# Altium schematic sheet Sheet1.SchDoc
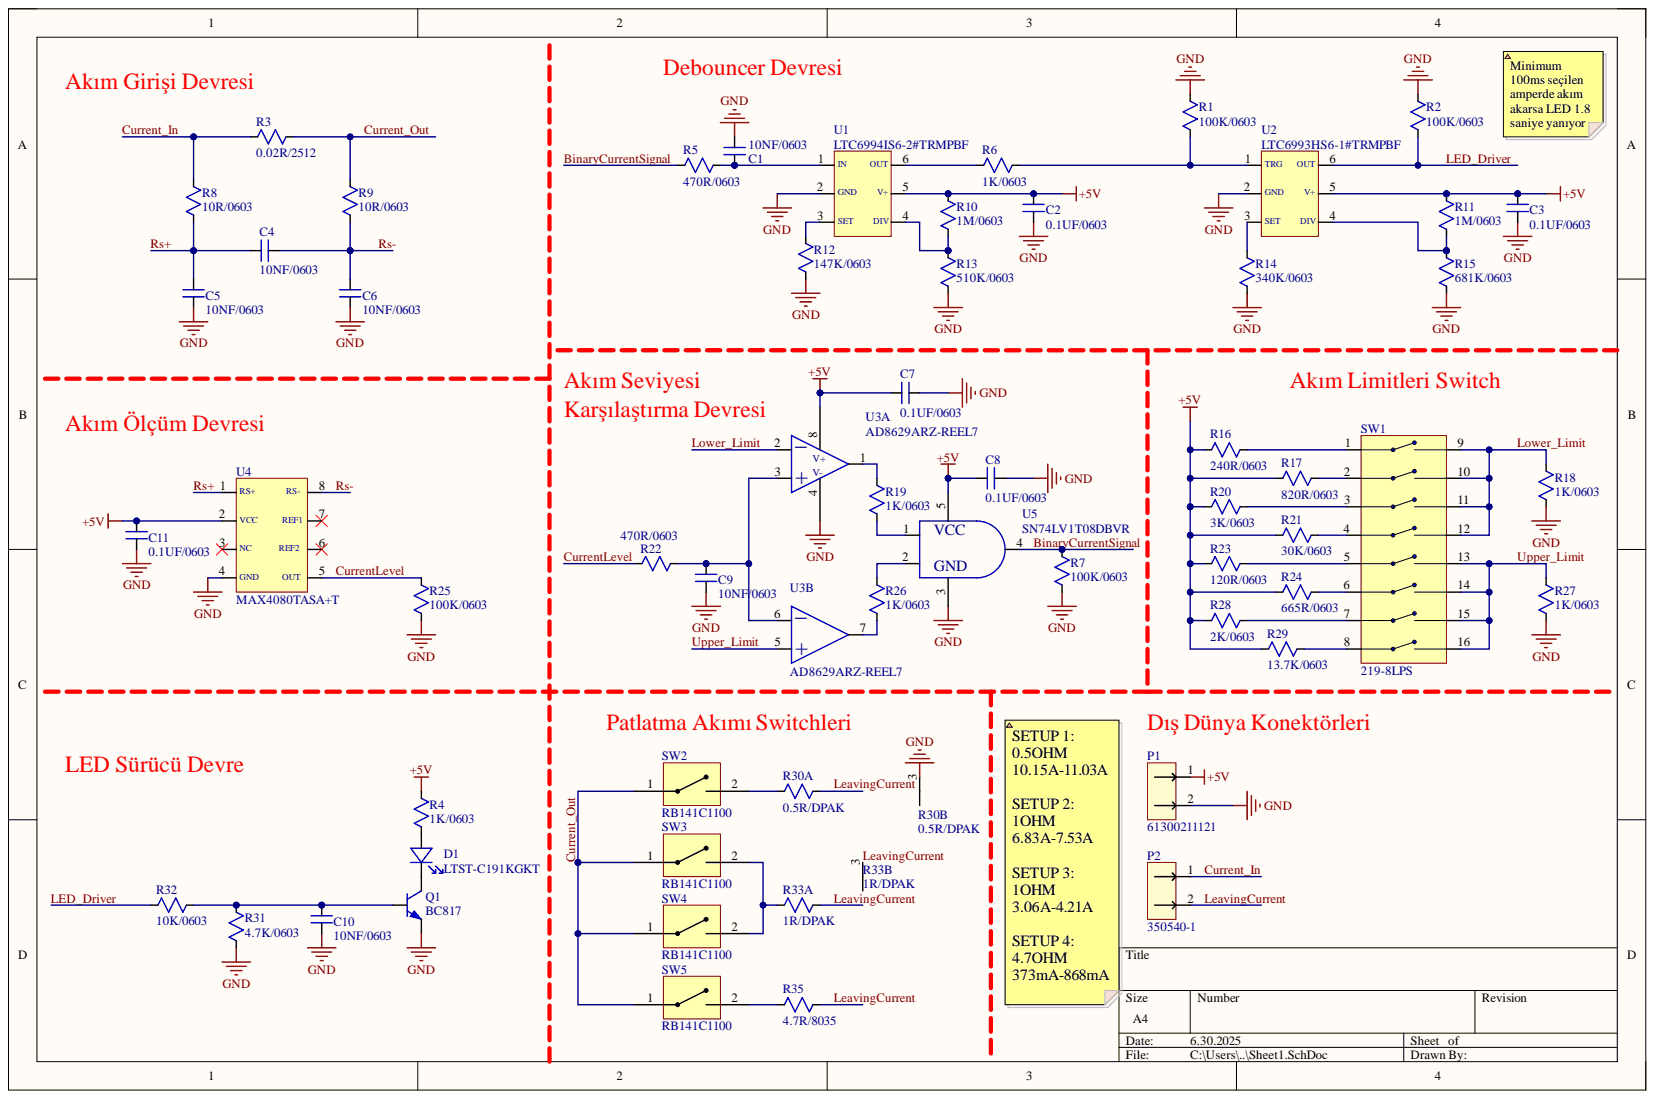
[diagram p0: Sheet1.SchDoc]

## Parts
C1  10NF/0603  [CAP 0603]
C2  0.1UF/0603  [CAP 0603]
C3  0.1UF/0603  [CAP 0603]
C4  10NF/0603  [CAP 0603]
C5  10NF/0603  [CAP 0603]
C6  10NF/0603  [CAP 0603]
C7  0.1UF/0603  [CAP 0603]
C8  0.1UF/0603  [CAP 0603]
C9  10NF/0603  [CAP 0603]
C10  10NF/0603  [CAP 0603]
C11  0.1UF/0603  [CAP 0603]
D1  LTST-C191KGKT  [LTST-C191KGKT]
P1  61300211121  [61300211121]
P2  350540-1  [350540-1]
Q1  BC817  [BC817-40,215]
R1  100K/0603  [RES0603]
R2  100K/0603  [RES0603]
R3  0.02R/2512  [RES2512]
R4  1K/0603  [RES0603]
R5  470R/0603  [RES0603]
R6  1K/0603  [RES0603]
R7  100K/0603  [RES0603]
R8  10R/0603  [RES0603]
R9  10R/0603  [RES0603]
R10  1M/0603  [RES0603]
R11  1M/0603  [RES0603]
R12  147K/0603  [RES0603]
R13  510K/0603  [RES0603]
R14  340K/0603  [RES0603]
R15  681K/0603  [RES0603]
R16  240R/0603  [RES0603]
R17  820R/0603  [RES0603]
R18  1K/0603  [RES0603]
R19  1K/0603  [RES0603]
R20  3K/0603  [RES0603]
R21  30K/0603  [RES0603]
R22  470R/0603  [RES0603]
R23  120R/0603  [RES0603]
R24  665R/0603  [RES0603]
R25  100K/0603  [RES0603]
R26  1K/0603  [RES0603]
R27  1K/0603  [RES0603]
R28  2K/0603  [RES0603]
R29  13.7K/0603  [RES0603]
R30  0.5R/DPAK  [PWR163S-25-R500FE]  (parts A,B)
R31  4.7K/0603  [RES0603]
R32  10K/0603  [RES0603]
R33  1R/DPAK  [PWR163S-25-1R00FE]  (parts A,B)
R35  4.7R/8035  [SM8035JT4R70]
SW1  219-8LPS  [219-8LPS]
SW2  RB141C1100  [RB141C1100]
SW3  RB141C1100  [RB141C1100]
SW4  RB141C1100  [RB141C1100]
SW5  RB141C1100  [RB141C1100]
U1  LTC6994IS6-2#TRMPBF  [LTC6994IS6-2#TRMPBF_1]
U2  LTC6993HS6-1#TRMPBF  [LTC6993HS6-1#TRMPBF]
U3  AD8629ARZ-REEL7  [AD8629ARZ_REEL7]  (parts A,B)
U4  MAX4080TASA+T  [MAX4080TASA+T]
U5  SN74LV1T08DBVR  [SN74LV1T08DBVR]

## Nets
+5V: C2.1 C3.1 C7.1 C8.2 C11.2 P1.1 R4.2 R10.2 R11.2 R16.1 R17.1 R20.2 R21.1 R23.1 R24.1 R28.1 R29.1 U1.5(V+) U2.5(V+) U3.8(V+) U4.2(VCC) U5.5
GND: C1.2 C2.2 C3.2 C5.1 C6.1 C7.2 C8.1 C9.1 C10.1 C11.1 P1.2 Q1.2 R1.2 R2.2 R7.1 R12.1 R13.1 R14.1 R15.1 R18.1 R25.1 R27.1 R30.3 R31.1 U1.2(GND) U2.2(GND) U3.4(V-) U4.4(GND) U5.3
BinaryCurrentSignal: R5.1 R7.2 U5.4
Current_In: P2.1 R3.1 R8.2
Current_Out: R3.2 R9.2 SW2.1 SW3.1 SW4.1 SW5.1
CurrentLevel: R22.1 R25.2 U4.5(OUT)
LeavingCurrent: P2.2 R30.2 R33.2 R33.3 R35.2
LED_Driver: R2.1 R32.2 U2.6(OUT)
Lower_Limit: R18.2 SW1.9 SW1.10 SW1.11 SW1.12 U3.2
Rs+: C4.2 C5.2 R8.1 U4.1(RS+)
Rs-: C4.1 C6.2 R9.1 U4.8(RS-)
Upper_Limit: R27.2 SW1.13 SW1.14 SW1.15 SW1.16 U3.5(+)
NetC1_1: C1.1 R5.2 U1.1(IN)
NetC9_2: C9.2 R22.2 U3.3(+) U3.6
NetC10_2: C10.2 Q1.1 R31.2 R32.1
NetD1_1: D1.1 R4.1
NetD1_2: D1.2 Q1.3
NetR1_1: R1.1 R6.2 U2.1(TRG)
NetR6_1: R6.1 U1.6(OUT)
NetR10_1: R10.1 R13.2 U1.4(DIV)
NetR11_1: R11.1 R15.2 U2.4(DIV)
NetR12_2: R12.2 U1.3(SET)
NetR14_2: R14.2 U2.3(SET)
NetR16_2: R16.2 SW1.1
NetR17_2: R17.2 SW1.2
NetR19_1: R19.1 U5.1(VCC)
NetR19_2: R19.2 U3.1
NetR20_1: R20.1 SW1.3
NetR21_2: R21.2 SW1.4
NetR23_2: R23.2 SW1.5
NetR24_2: R24.2 SW1.6
NetR26_1: R26.1 U3.7
NetR26_2: R26.2 U5.2(GND)
NetR28_2: R28.2 SW1.7
NetR29_2: R29.2 SW1.8
NetR30_1: R30.1 SW2.2
NetR33_1: R33.1 SW3.2 SW4.2
NetR35_1: R35.1 SW5.2

Not connected: U4 3(NC) 6(REF2) 7(REF1)

## Library data of the parts
C1 C4-C6 C9 C10 = CC0603KPX7R9BB103: CAP CER 10000PF 50V X7R 0603; Component Kind: Standard; Library Name: PA_Test_Kartı_v2.SchLib
C2 C3 C7 C8 C11 = CC0603KPX7R9BB104: CAP CER 0.1UF 50V X7R 0603; Component Kind: Standard; Library Name: PA_Test_Kartı_v2.SchLib
D1 = LTST-C191KGKT: LED GREEN CLEAR SMD; Component Kind: Standard; Library Name: PA_Test_Kartı_v2.SchLib
P1 = 61300211121: CONN HEADER VERT 2POS 2.54MM 3A; Component Kind: Standard; Library Name: PA_Test_Kartı_v2.SchLib
P2 = 350540-1: CONN HEADER VERT 2POS 5.08MM 12A; Component Kind: Standard; Library Name: PA_Test_Kartı_v2.SchLib
Q1 = BC817-40,215: TRANS NPN 45V 0.5A TO236AB; Component Kind: Standard; Library Name: PA_Test_Kartı_v2.SchLib
R1 R2 R7 R25 = RC0603FR-07100KL: RES SMD 100 KOHM 1% 1/16W 0603; Component Kind: Standard
  R7 R25: Library Name: Integrated_Library1.IntLib
  R1 R2: Library Name: PA_Test_Kartı_v2.SchLib
R3 = CRA2512-FZ-R020ELF: RES POWER 0.02 OHM 1% 3W  CURR SENSE 2512; Component Kind: Standard; Library Name: PA_Test_Kartı_v2.SchLib
R4 R6 R18 R19 R26 R27 = RT0603BRD131KL: RES SMD 1K OHM 0.1% 1/10W 0603; Component Kind: Standard; Library Name: PA_Test_Kartı_v2.SchLib
R5 R22 = RC0603JR-07470RL: RES SMD 470 OHM 5% 1/10W 0603; Component Kind: Standard; Library Name: PA_Test_Kartı_v2.SchLib
R8 R9 = RT0603BRD0710RL: RES SMD 10 OHM 0.1% 1/10W 0603; Component Kind: Standard; Library Name: PA_Test_Kartı_v2.SchLib
R10 R11 = ERJ-3EKF1004V: RES SMD 1M OHM 1% 1/10W 0603; Component Kind: Standard; Library Name: PA_Test_Kartı_v2.SchLib
R12 = RC0603FR-07147KL: RES SMD 147K OHM 1% 1/16W 0603; Component Kind: Standard; Library Name: Integrated_Library1.IntLib
R13 = RC0603FR-07510KL: RES SMD 510K OHM 1% 1/10W 0603; Component Kind: Standard; Library Name: PA_Test_Kartı_v2.SchLib
R14 = RC0603FR-07340KL: RES SMD 340K OHM 1% 1/10W 0603; Component Kind: Standard; Library Name: PA_Test_Kartı_v2.SchLib
R15 = RMCF0603FT681K: RES  681K OHM 1% 1/10W 0603; Component Kind: Standard; Library Name: PA_Test_Kartı_v2.SchLib
R16 = RC0603FR-07240RL: RES SMD 240 OHM 1% 1/10W 0603; Component Kind: Standard; Library Name: PA_Test_Kartı_v2.SchLib
R17 = RC0603FR-07820RL: RES SMD 820 OHM 1% 1/10W 0603; Component Kind: Standard; Library Name: PA_Test_Kartı_v2.SchLib
R20 = ERJ-PA3J302V: RES SMD 3K OHM 5% 1/3W 0603; Component Kind: Standard; Library Name: PA_Test_Kartı_v2.SchLib
R21 = RC0603FR-1330KL: RES SMD 30K OHM 1% 1/10W 0603; Component Kind: Standard; Library Name: PA_Test_Kartı_v2.SchLib
R23 = ERA-3AEB121V: RES SMD 120 OHM 0.1% 1/10W 0603; Component Kind: Standard; Library Name: PA_Test_Kartı_v2.SchLib
R24 = RC0603FR-07665RL: RES SMD 665 OHM 1% 1/10W 0603; Component Kind: Standard; Library Name: PA_Test_Kartı_v2.SchLib
R28 = ERA-3AEB202V: RES SMD 2K OHM 0.1% 1/10W 0603; Component Kind: Standard; Library Name: PA_Test_Kartı_v2.SchLib
R29 = RMCF0603FT13K7: RES  13.7K OHM 1% 1/10W 0603; Component Kind: Standard; Library Name: PA_Test_Kartı_v2.SchLib
R30 = PWR163S-25-R500FE: RES POWER 0.5 OHM  25W 1% Non-Standart DPAK; Component Kind: Standard; Library Name: Integrated_Library1.IntLib
R31 = RC0603JR-074K7L: RES SMD 4.7K OHM 5% 1/16W 0603; Component Kind: Standard; Library Name: Integrated_Library1.IntLib
R32 = RT0603BRD0710KL: RES SMD 10K OHM 0.1% 1/10W 0603; Component Kind: Standard; Library Name: PA_Test_Kartı_v2.SchLib
R33 = PWR163S-25-1R00FE: RES POWER  1 OHM  25W 1% Non-Standart DPAK; Component Kind: Standard; Library Name: Integrated_Library1.IntLib
R35 = SM8035JT4R70: RES POWER 4.7 OHM  4W 5% 8035 J-Lead; Component Kind: Standard; Library Name: PA_Test_Kartı_v2.SchLib
SW1 = 219-8LPS: SWITCH 100mA, 20 VDC, DIP 8 SPST IC; Component Kind: Standard; Library Name: PA_Test_Kartı_v2.SchLib
SW2-SW5 = RB141C1100: SWITCH ROCKER SPST 15A 125V; Component Kind: Standard; Library Name: PA_Test_Kartı_v2.SchLib
U3 = AD8629ARZ-REEL7: IC OPAMP ZERO-DRIFT 2 CIRC 8SOIC; Component Kind: Standard; Library Name: PA_Test_Kartı_v2.SchLib
U5 = SN74LV1T08DBVR: 1CH 2INP AND-Gate 5V; Component Kind: Standard; Library Name: PA_Test_Kartı_v2.SchLib
U1 = LTC6994IS6-2#TRMPBF: Component Kind: Standard; Library Name: PA_Test_Kartı_v2.SchLib
U2 = LTC6993HS6-1#TRMPBF: Component Kind: Standard; Library Name: PA_Test_Kartı_v2.SchLib
U4 = MAX4080TASA+T: Component Kind: Standard; Library Name: Integrated_Library1.IntLib
